# Altium schematic sheet top.SchDoc(top)
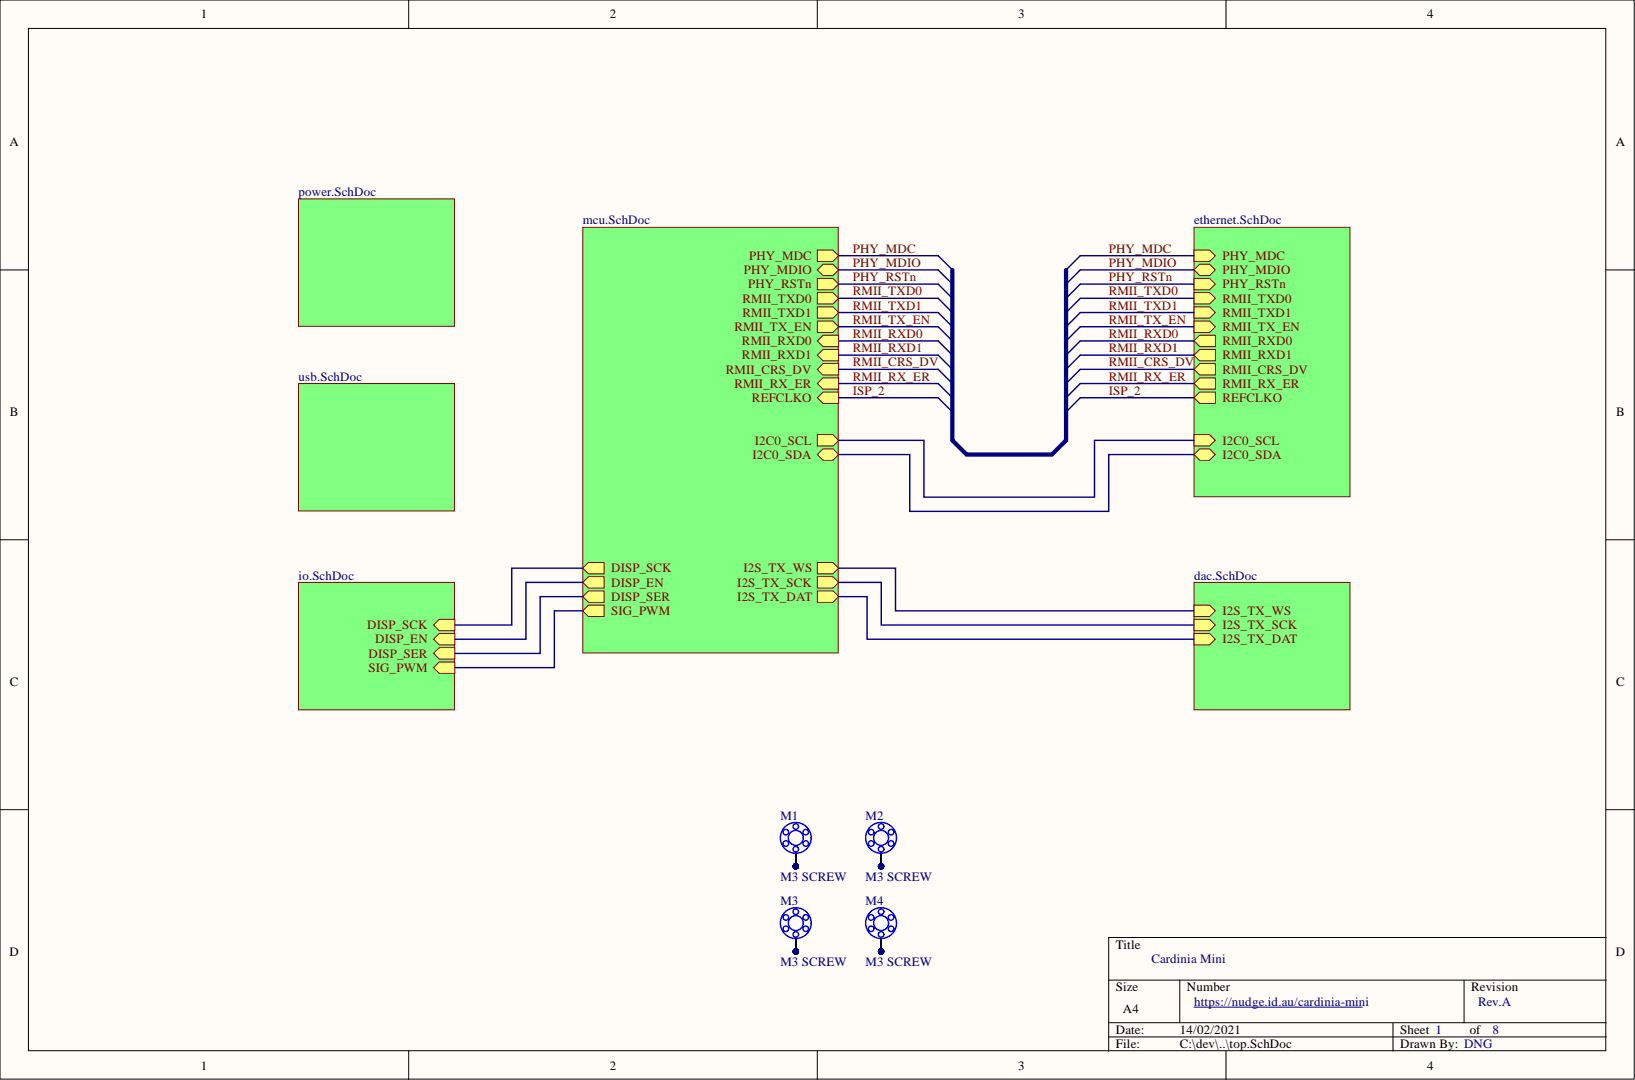
[diagram p0: top.SchDoc]

## Parts
M1  M3 SCREW  [M3_SCREW]  (no BOM)
M2  M3 SCREW  [M3_SCREW]  (no BOM)
M3  M3 SCREW  [M3_SCREW]  (no BOM)
M4  M3 SCREW  [M3_SCREW]  (no BOM)

## Nets
NetM1_1: M1.1 M1.2 M1.3 M1.4 M1.5 M1.6 M1.7
NetM2_1: M2.1 M2.2 M2.3 M2.4 M2.5 M2.6 M2.7
NetM3_1: M3.1 M3.2 M3.3 M3.4 M3.5 M3.6 M3.7
NetM4_1: M4.1 M4.2 M4.3 M4.4 M4.5 M4.6 M4.7
DISP_EN:
DISP_SCK:
DISP_SER:
I2C0_SCL:
I2C0_SDA:
I2S_TX_DAT:
I2S_TX_SCK:
I2S_TX_WS:
N00191:
PHY_MDC:
PHY_MDIO:
PHY_RSTn:
REFCLKO:
RMII_CRS_DV:
RMII_RX_ER:
RMII_RXD0:
RMII_RXD1:
RMII_TX_EN:
RMII_TXD0:
RMII_TXD1:
SIG_PWM:

## Library data of the parts
M1-M4 = MOUNTING: MOUNTING HOLE - NON INSTALLABLE; Library Name: vh_altium_library.intLib
